# Altium schematic sheet NXP NXPhlite-Connectors 3.0RC18.SCH
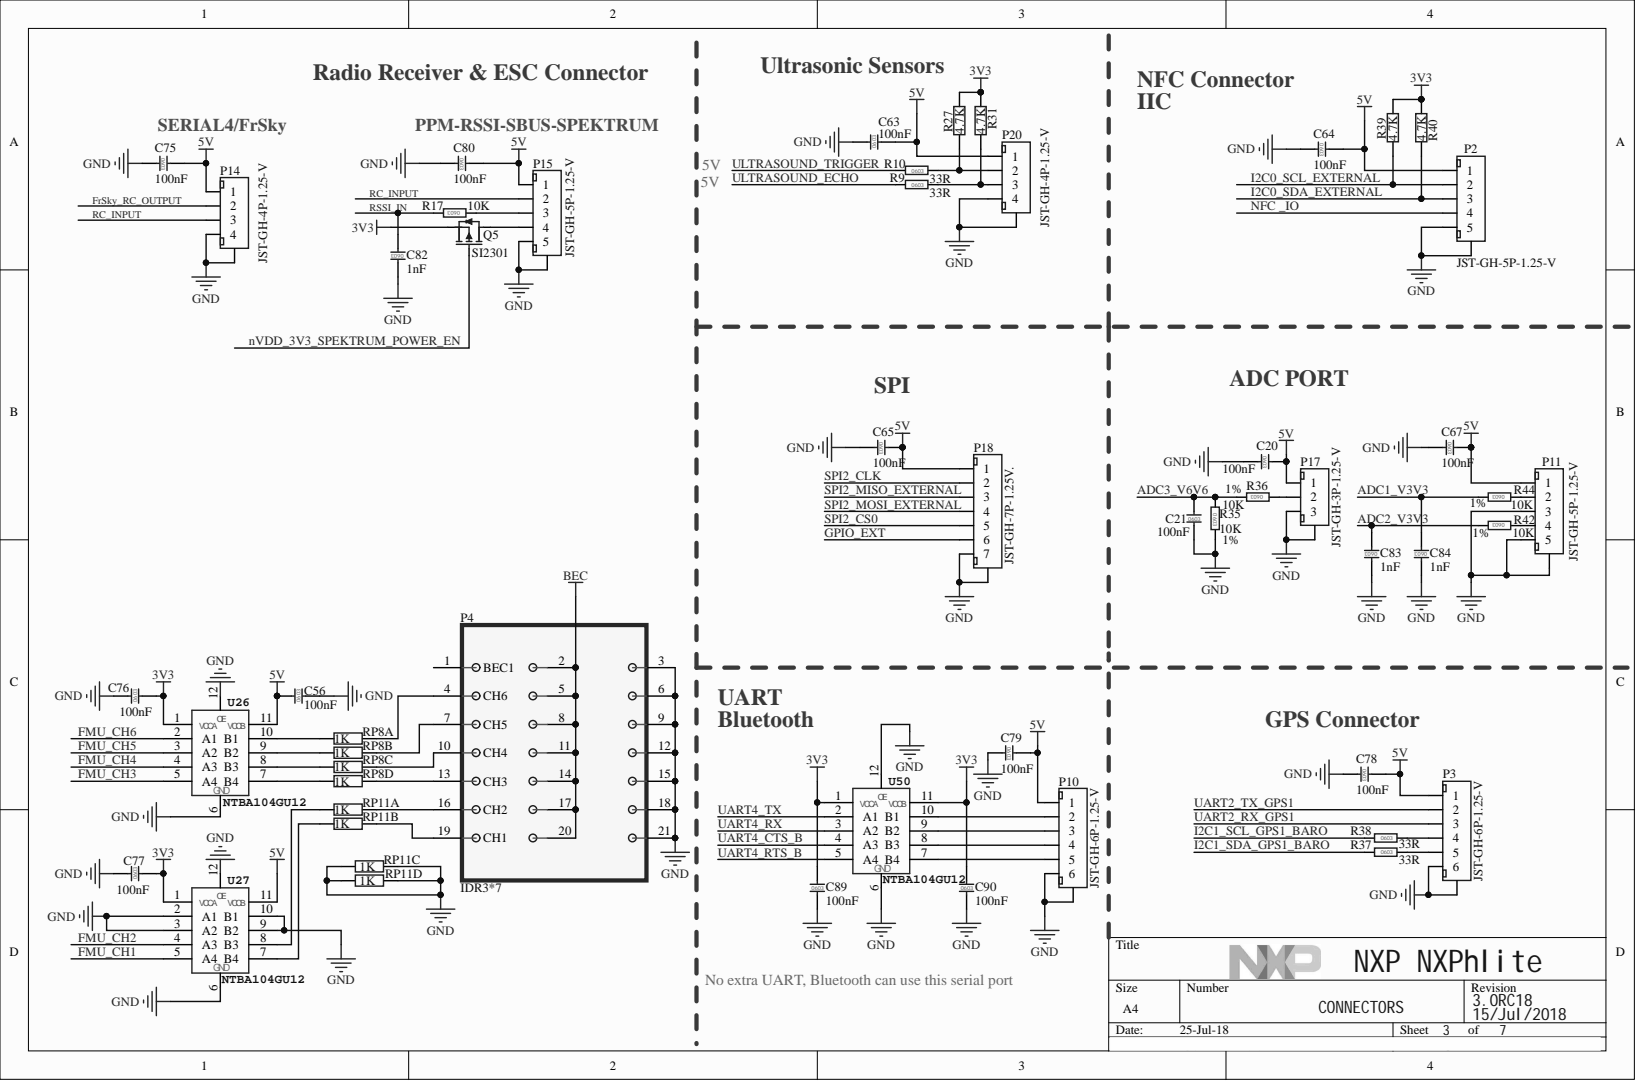
[diagram p0: NXP NXPhlite-Connectors 3.0RC18.SCH]

## Parts
C20  100nF  [0603C]
C21  100nF  [0603C]
C56  100nF  [0603C]
C63  100nF  [0603C]
C64  100nF  [0603C]
C65  100nF  [0603C]
C67  100nF  [0603C]
C75  100nF  [0603C]
C76  100nF  [0603C]
C77  100nF  [0603C]
C78  100nF  [0603C]
C79  100nF  [0603C]
C80  100nF  [0603C]
C82  1nF  [0603C]
C83  1nF  [0603C]
C84  1nF  [0603C]
C89  100nF  [0603C]
C90  100nF  [0603C]
P2  JST-GH-5P-1.25-V  [JST-5P-1.25]
P3  JST-GH-6P-1.25-V  [JST-6P-1.25]
P4  IDR3*7  [PCBComponent_1 - duplicate]
P10  JST-GH-6P-1.25-V  [JST-6P-1.25]
P11  JST-GH-5P-1.25-V  [JST-5P-1.25]
P14  JST-GH-4P-1.25-V  [JST-4P-1.25]
P15  JST-GH-5P-1.25-V  [JST-5P-1.25]
P17  JST-GH-3P-1.25-V  [JST-3P-1.25]
P18  JST-GH-7P-1.25V.  [JST-7P-1.25]
P20  JST-GH-4P-1.25-V  [JST-4P-1.25]
Q5  SI2301  [SOT-23]
R9  33R  [0603R]
R10  33R  [0603R]
R17  10K  [0603R]
R27  4.7K  [0603R]
R31  4.7K  [0603R]
R35  10K  [0603R]
R36  10K  [0603R]
R37  33R  [0603R]
R38  33R  [0603R]
R39  4.7K  [0603R]
R40  4.7K  [0603R]
R42  10K  [0603R]
R44  10K  [0603R]
RP8  1K  [RN8-0402]  (parts A,B,C,D)
RP11  1K  [RN8-0402]  (parts A,B,C,D)
U26  NTBA104GU12  [XQFN12]
U27  NTBA104GU12  [XQFN12]
U50  NTBA104GU12  [XQFN12]

## Nets
3V3: C76.2 C77.2 C89.1 C90.1 Q5.2 R27.1 R31.1 R39.1 R40.1 U26.1(VCCA) U27.1(VCCA) U50.1(VCCA) U50.11(VCCB)
5V: C20.1 C56.1 C63.2 C64.1 C65.1 C67.1 C75.1 C78.1 C79.1 C80.1 P2.1 P3.1 P10.1 P11.1 P14.1 P15.1 P17.1 P18.1 P20.1 U26.11(VCCB) U27.11(VCCB)
BEC: P4.2 P4.5 P4.8 P4.11 P4.14 P4.17 P4.20
GND: C20.2 C21.2 C56.2 C63.1 C64.2 C65.2 C67.2 C75.2 C76.1 C77.1 C78.2 C79.2 C80.2 C82.1 C83.1 C84.1 C89.2 C90.2 P2.0 P2.5 P3.0 P3.6 P4.3 P4.6 P4.9 P4.12 P4.15 P4.18 P4.21 P10.0 P10.6 P11.0 P11.3 P11.5 P14.0 P14.4 P15.0 P15.5 P17.0 P17.3 P18.0 P18.7 P20.0 P20.4 R35.1 RP11.3 RP11.4 RP11.5 RP11.6 U26.6 U26.12(OE) U27.2(A1) U27.3(A2) U27.6 U27.9(B2) U27.10(B1) U27.12(OE) U50.6 U50.12(OE)
ADC1_V3V3: C84.2 R44.1
ADC2_V3V3: C83.2 R42.1
ADC3_V6V6: C21.1 R35.2 R36.1
FMU_CH1: U27.5(A4)
FMU_CH2: U27.4(A3)
FMU_CH3: U26.5(A4)
FMU_CH4: U26.4(A3)
FMU_CH5: U26.3(A2)
FMU_CH6: U26.2(A1)
FrSky_RC_OUTPUT: P14.2
GPIO_EXT: P18.6
I2C0_SCL_EXTERNAL: P2.2 R39.2
I2C0_SDA_EXTERNAL: P2.3 R40.2
I2C1_SCL_GPS1_BARO: R38.2
I2C1_SDA_GPS1_BARO: R37.2
NFC _IO: P2.4
nVDD_3V3_SPEKTRUM_POWER_EN: Q5.1
RC_INPUT: P14.3 P15.2
RSSI_IN: C82.2 R17.1
SPI2_CLK: P18.2
SPI2_CS0: P18.5
SPI2_MISO_EXTERNAL: P18.3
SPI2_MOSI_EXTERNAL: P18.4
UART2_RX_GPS1: P3.3
UART2_TX_GPS1: P3.2
UART4_CTS_B: U50.4(A3)
UART4_RTS_B: U50.5(A4)
UART4_RX: U50.3(A2)
UART4_TX: U50.2(A1)
ULTRASOUND_ECHO: R9.2
ULTRASOUND_TRIGGER: R10.2
NetP3_4: P3.4 R38.1
NetP3_5: P3.5 R37.1
NetP4_4: P4.4(CH6) RP8.8
NetP4_7: P4.7(CH5) RP8.7
NetP4_10: P4.10(CH4) RP8.6
NetP4_13: P4.13(CH3) RP8.5
NetP4_16: P4.16(CH2) RP11.8
NetP4_19: P4.19(CH1) RP11.7
NetP10_2: P10.2 U50.10(B1)
NetP10_3: P10.3 U50.9(B2)
NetP10_4: P10.4 U50.8(B3)
NetP10_5: P10.5 U50.7(B4)
NetP11_2: P11.2 R44.2
NetP11_4: P11.4 R42.2
NetP15_3: P15.3 R17.2
NetP15_4: P15.4 Q5.3
NetP17_2: P17.2 R36.2
NetP20_2: P20.2 R10.1 R27.2
NetP20_3: P20.3 R9.1 R31.2
NetRP8_1: RP8.1 U26.10(B1)
NetRP8_2: RP8.2 U26.9(B2)
NetRP8_3: RP8.3 U26.8(B3)
NetRP8_4: RP8.4 U26.7(B4)
NetRP11_1: RP11.1 U27.8(B3)
NetRP11_2: RP11.2 U27.7(B4)

Not connected: P4 1(BEC1)

## Library data of the parts
C20 C21 C56 C63-C65 C67 C75-C80 C89 C90 = Cap_0603: CAP CER 0.1uF 16V 10% X7R AEC-Q200 0603; Manufacturer: MURATA; Manufacturer PN: GCM188R71C104KA37D; Agile PN: 150-79338; Component Kind: Standard; Library Name: LZ SchLib V1.0.SchLib
C82-C84 = Cap_0603: CAP CER 1000pF 25V 10% X7R 0603; Manufacturer: Yageo; Manufacturer PN: CC0603KRX7R8BB102; Agile PN: 150-78736; Component Kind: Standard; Library Name: LZ SchLib V1.0.SchLib
P2 P11 P15 = XH2.54_5P: CONN HEADER GH TOP 5POS 1.25MM; Manufacturer: JST Sales America Inc; Manufacturer PN: BM05B-GHS-TBT(LF)(SN)(N); Agile PN: TBD; Component Kind: Standard; Library Name: LANDZO flight controller V1.1.SCHLIB
P3 P10 = XH2.54_6P: CONN HEADER GH TOP 6POS 1.25MM; Manufacturer: JST Sales America Inc; Manufacturer PN: BM06B-GHS-TBT(LF)(SN)(N); Agile PN: TBD; Component Kind: Standard; Library Name: LANDZO flight controller V1.1.SCHLIB
P4 = IDR3*7: CON 3X7 TH 100 MIL RA; Manufacturer: SAMTEC; Manufacturer PN: TSW-107-08-T-T-RA; Agile PN: TBD; Component Kind: Standard; Library Name: NXP NXPhlite V3.2.SCHLIB
P14 P20 = XH2.54_4P: CONN HEADER GH TOP 4POS 1.25MM; Manufacturer: JST Sales America Inc; Manufacturer PN: BM04B-GHS-TBT(LF)(SN)(N); Agile PN: TBD; Component Kind: Standard; Library Name: LANDZO flight controller V1.1.SCHLIB
P17 = XH2.54_3P: CONN HEADER GH TOP 3POS 1.25MM; Manufacturer: JST Sales America Inc; Manufacturer PN: BM03B-GHS-TBT(LF)(SN)(N); Agile PN: TBD; Component Kind: Standard; Library Name: LANDZO flight controller V1.1.SCHLIB
P18 = XH2.54_7P: CONN HEADER GH TOP 7POS 1.25MM; Manufacturer: JST Sales America Inc; Manufacturer PN: BM07B-GHS-TBT(LF)(SN)(N); Agile PN: TBD; Component Kind: Standard; Library Name: NXP FMU V2.4.SCHLIB
Q5 = MOSFET-P: MOSFET P-CH 20V 3.1A SOT23-3; Manufacturer: VISHAY INTERTECHNOLOGY; Manufacturer PN: Si2301CDS; Agile PN: TBD; Component Kind: Standard; Simulation: PMOS; Library Name: NXP NXPhlite V3.2.SCHLIB
R9 R10 R37 R38 = Res_0603: RES MF 33 OHM 1/8W 1% AEC-Q200 0603; Manufacturer: KOA SPEER; Manufacturer PN: RK73H1JTTD33R0F; Agile Pn: 470-81926; Component Kind: Standard; Library Name: LZ SchLib V1.0.SchLib
R17 R35 R36 R42 R44 = Res_0603: RES MF 10.0K 1/10W 1% 0603; Manufacturer: Yageo; Manufacturer PN: RC0603FR-0710KL; Agile PN: 470-75422; Component Kind: Standard; Library Name: LZ SchLib V1.0.SchLib
R27 R31 R39 R40 = Res: RES MF 4.7K 1/10W 1% AEC-Q200 0603; Manufacturer: KOA SPEER; Manufacturer PN: RK73H1JTTD4701F; Agile PN: 470-81511; Component Kind: Standard; Library Name: SchLib.SchLib
RP8 RP11 = Res-Pack2: RES ARRAY 4 RES 1K OHM 0804; Manufacturer: Vishay Dale; Manufacturer PN: CRA04S0831K00FTD; Agile PN: TBD; Component Kind: Standard; Library Name: LZ SchLib V1.0.SchLib
U26 U27 U50 = NTBA104: IC TRANSLATING XCVR 1.2-3.6VV/1.65-5.5V XQFN12; Manufacturer: Nexperia; Manufacturer PN: NTBA104GU12,115; Agile PN: 312-81236; Component Kind: Standard; Library Name: NXP FMU V2.3.SCHLIB
